# Altium schematic sheet 102-002-00139-00,K12人体传感器V1.0原理图.SchDoc
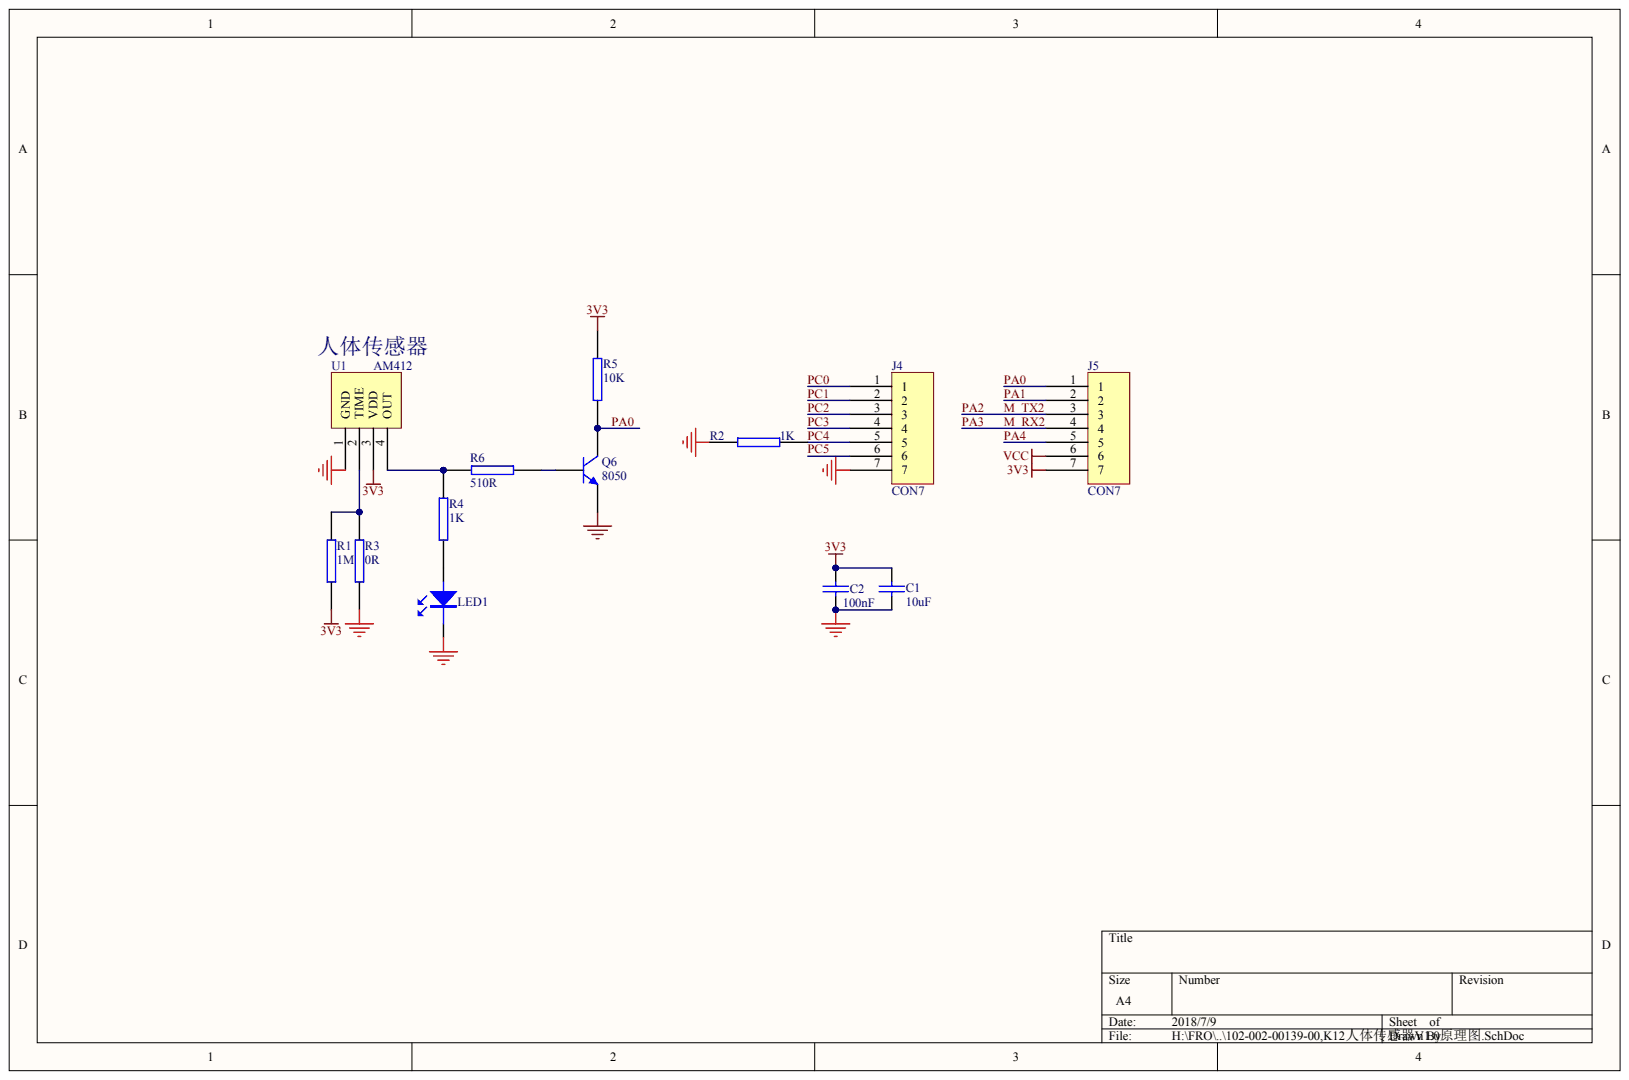
[diagram p0: 102-002-00139-00,K12人体传感器V1.0原理图.SchDoc]

## Parts
C1  10uF
C2  100nF
J4  CON7
J5  CON7
LED1  BLUE  (value not drawn)
Q6  8050
R1  1M
R2  1K
R3  0R
R4  1K
R5  10K
R6  510R
U1  AM412

## Nets
3V3: C1.2 C2.2 J5.7 R1.1 R5.2 U1.3(VDD)
GND: C1.1 C2.1 J4.7 LED1.K Q6.2 R2.1 R3.1 U1.1(GND)
VCC: J5.6
M_RX2: J5.4
M_TX2: J5.3
PA0: J5.1 Q6.3 R5.1
PA1: J5.2
PA4: J5.5
PC0: J4.1
PC1: J4.2
PC2: J4.3
PC3: J4.4
PC4: J4.5 R2.2
PC5: J4.6
NetLED1_A: LED1.A R4.1
NetQ6_1: Q6.1 R6.1
NetR1_2: R1.2 R3.2 U1.2(TIME)
NetR4_2: R4.2 R6.2 U1.4(OUT)

## Library data of the parts
C1 = (no symbol name): wuliaobianma: 104-001-00106-16; wuliaoguige: 0805/10uF/16V/X5R/J; wuliaomingchen: 贴片无极电容
C2 = (no symbol name): wuliaobianma: 104-001-00104-50; wuliaoguige: 0805/100nF/50V/X7R/J; wuliaomingchen: 贴片无极电容
LED1 = (no symbol name): wuliaobianma: 106-002-00005-00; wuliaoguige: 0805/blue; wuliaomingchen: 贴片高亮发光二极管
Q6 = (no symbol name): wuliaobianma: 107-001-00001-00; wuliaoguige: S8050/SOT-23; wuliaomingchen: 贴片三极管
R1 = (no symbol name): wuliaobianma: 103-001-00105-01; wuliaoguige: 0805/1MΩ/F; wuliaomingchen: 贴片电阻
R2 R4 = (no symbol name): wuliaobianma: 103-001-00102-01; wuliaoguige: 0805/1KΩ/F; wuliaomingchen: 贴片电阻
R3 = (no symbol name): wuliaobianma: 103-001-00000-01; wuliaoguige: 0805/0Ω/F; wuliaomingchen: 贴片电阻
R5 = (no symbol name): wuliaobianma: 103-001-00103-01; wuliaoguige: 0805/10KΩ/F; wuliaomingchen: 贴片电阻
R6 = (no symbol name): wuliaobianma: 103-001-00511-01; wuliaoguige: 0805/510Ω/F; wuliaomingchen: 贴片电阻
